# Altium schematic sheet ESP32.SchDoc(ESP32)
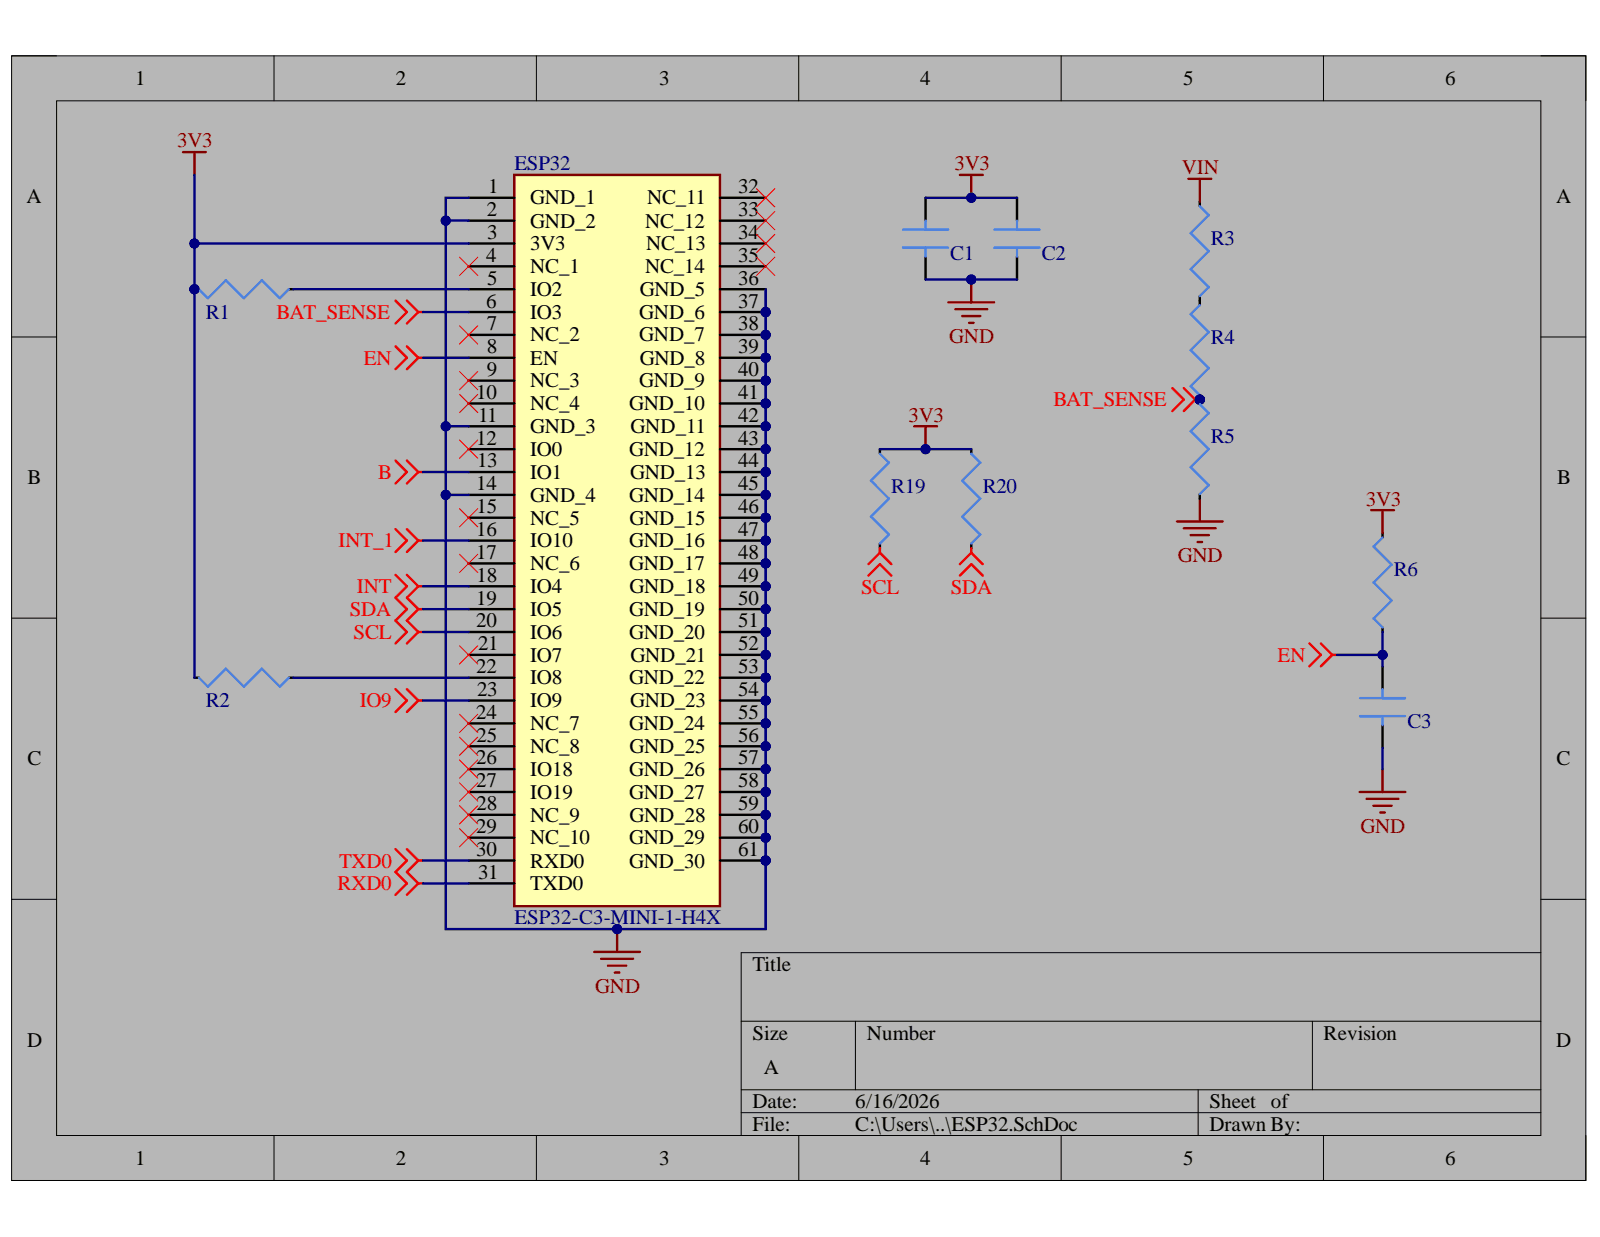
[diagram p0: ESP32.SchDoc]

## Parts
C1  10uF 0402  [0402 Cap]  (value not drawn)
C2  0.1uF 0402  [0402 Cap]  (value not drawn)
C3  1uF 0402  [0402 Cap]  (value not drawn)
ESP32  ESP32-C3-MINI-1-H4X  [ESP32C3MINI1H4X]
R1  10K Ohm 5% 0402  [0402_RESISTOR]  (value not drawn)
R2  10K Ohm 5% 0402  [0402_RESISTOR]  (value not drawn)
R3  180K 0402 Resistor  [0402_RESISTOR]  (value not drawn)
R4  220K 0402 Resistor  [0402_RESISTOR]  (value not drawn)
R5  100K 0402 Resistor  [0402_RESISTOR]  (value not drawn)
R6  10K Ohm 5% 0402  [0402_RESISTOR]  (value not drawn)
R19  10K Ohm 5% 0402  [0402_RESISTOR]  (value not drawn)
R20  10K Ohm 5% 0402  [0402_RESISTOR]  (value not drawn)

## Nets
3V3: C1.2 C2.2 ESP32.3(3V3) R1.1 R2.1 R6.1 R19.2 R20.2
B: ESP32.13(IO1)
BAT_SENSE: ESP32.6(IO3) R4.2 R5.2
EN: C3.2 ESP32.8(EN) R6.2
GND: C1.1 C2.1 C3.1 ESP32.1(GND_1) ESP32.2(GND_2) ESP32.11(GND_3) ESP32.14(GND_4) ESP32.36(GND_5) ESP32.37(GND_6) ESP32.38(GND_7) ESP32.39(GND_8) ESP32.40(GND_9) ESP32.41(GND_10) ESP32.42(GND_11) ESP32.43(GND_12) ESP32.44(GND_13) ESP32.45(GND_14) ESP32.46(GND_15) ESP32.47(GND_16) ESP32.48(GND_17) ESP32.49(GND_18) ESP32.50(GND_19) ESP32.51(GND_20) ESP32.52(GND_21) ESP32.53(GND_22) ESP32.54(GND_23) ESP32.55(GND_24) ESP32.56(GND_25) ESP32.57(GND_26) ESP32.58(GND_27) ESP32.59(GND_28) ESP32.60(GND_29) ESP32.61(GND_30) R5.1
INT: ESP32.18(IO4)
INT_1: ESP32.16(IO10)
IO9: ESP32.23(IO9)
RXD0: ESP32.31(TXD0)
SCL: ESP32.20(IO6) R19.1
SDA: ESP32.19(IO5) R20.1
TXD0: ESP32.30(RXD0)
VIN: R3.2
NetESP32_5: ESP32.5(IO2) R1.2
NetESP32_22: ESP32.22(IO8) R2.2
NetR3_1: R3.1 R4.1

Not connected: ESP32 4(NC_1) 7(NC_2) 9(NC_3) 10(NC_4) 12(IO0) 15(NC_5) 17(NC_6) 21(IO7) 24(NC_7) 25(NC_8) 26(IO18) 27(IO19) 28(NC_9) 29(NC_10) 32(NC_11) 33(NC_12) 34(NC_13) 35(NC_14)

## Library data of the parts
C1-C3 = Cap_0402: Component Kind: Standard; Library Name: custom.SchLib
ESP32 = ESP32-C3-MINI-1-H4X: Undefined or Miscellaneous; Manufacturer_Name: Espressif Systems; Component Kind: Standard; Datasheet Link: https://www.mouser.com/catalog/specsheets/Espressif_Systems_esp32-c3-mini-1_datasheet_en.pdf; Height: 2.55mm; Manufacturer_Part_Number: ESP32-C3-MINI-1-H4X; Mouser Part Number: 356-ESP32C3MINI1H4X; Mouser Price/Stock: https://www.mouser.co.uk/ProductDetail/Espressif-Systems/ESP32-C3-MINI-1-H4X?qs=olJun0bQHM%252Bm8wbucOd%2FlA%3D%3D
R1-R6 R19 R20 = Resistor_0402: Component Kind: Standard; Library Name: custom.SchLib
